# Altium schematic sheet 04_CPU.SchDoc(04_CPU)
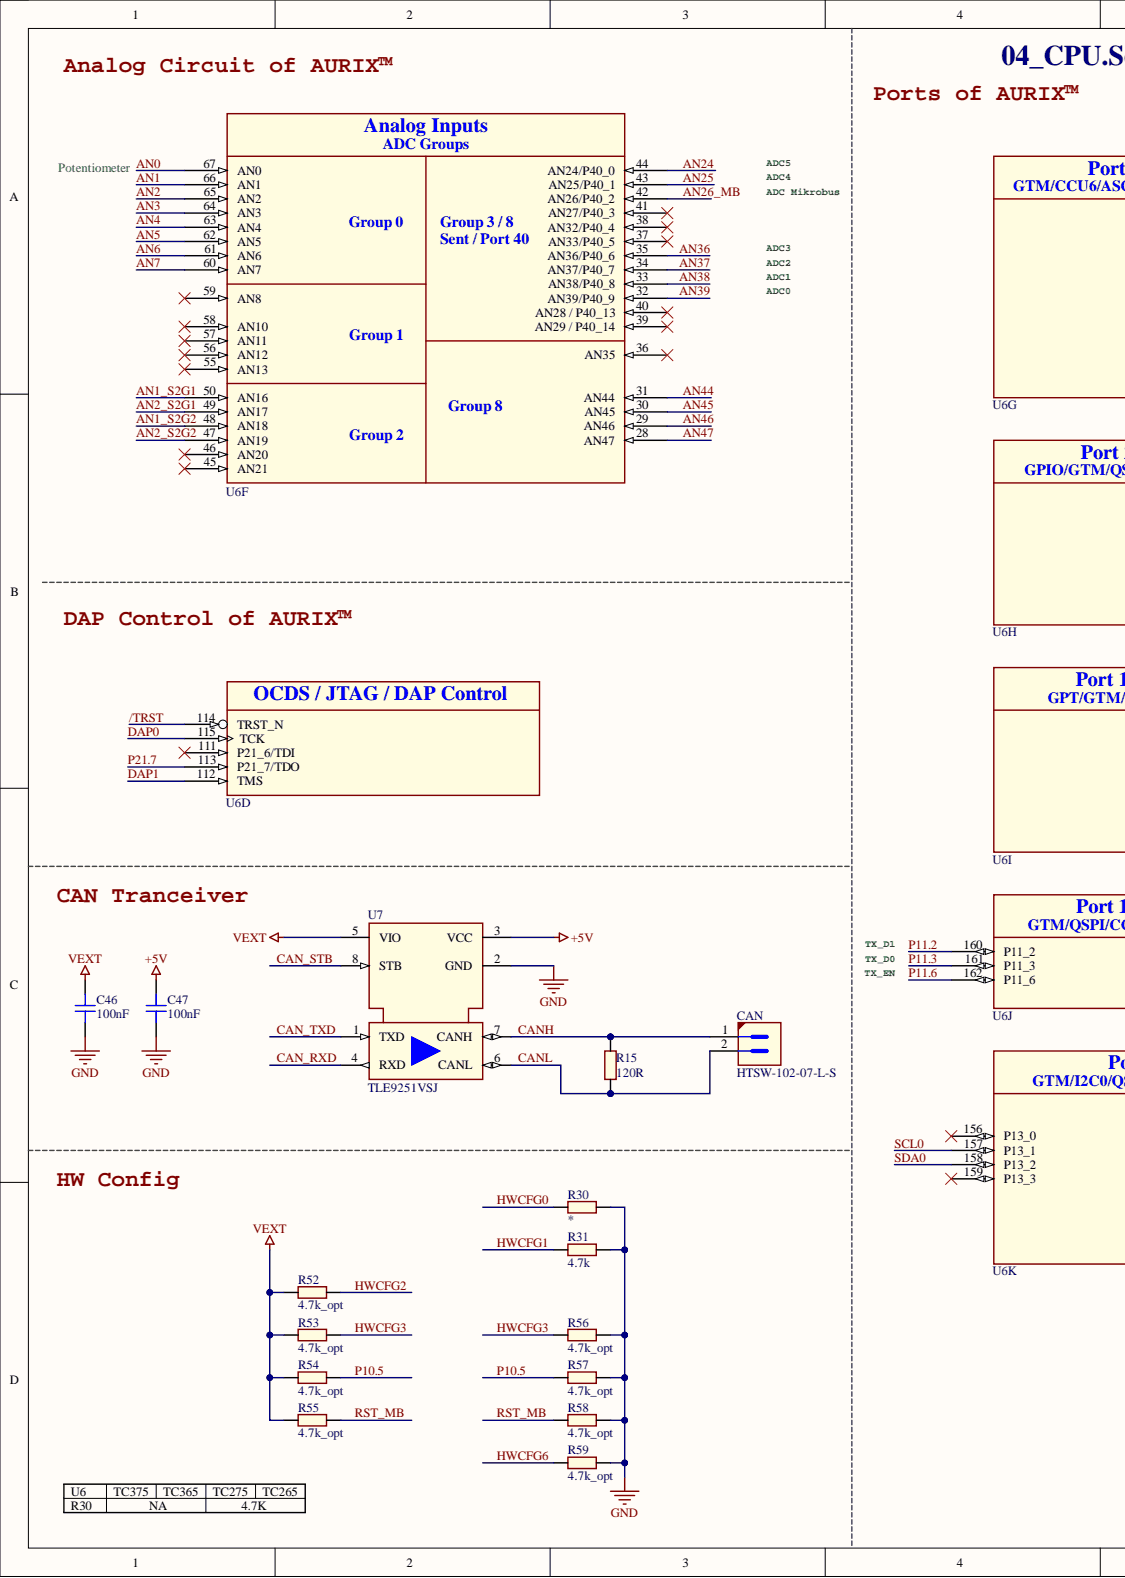
[diagram p0: 04_CPU.SchDoc, left]
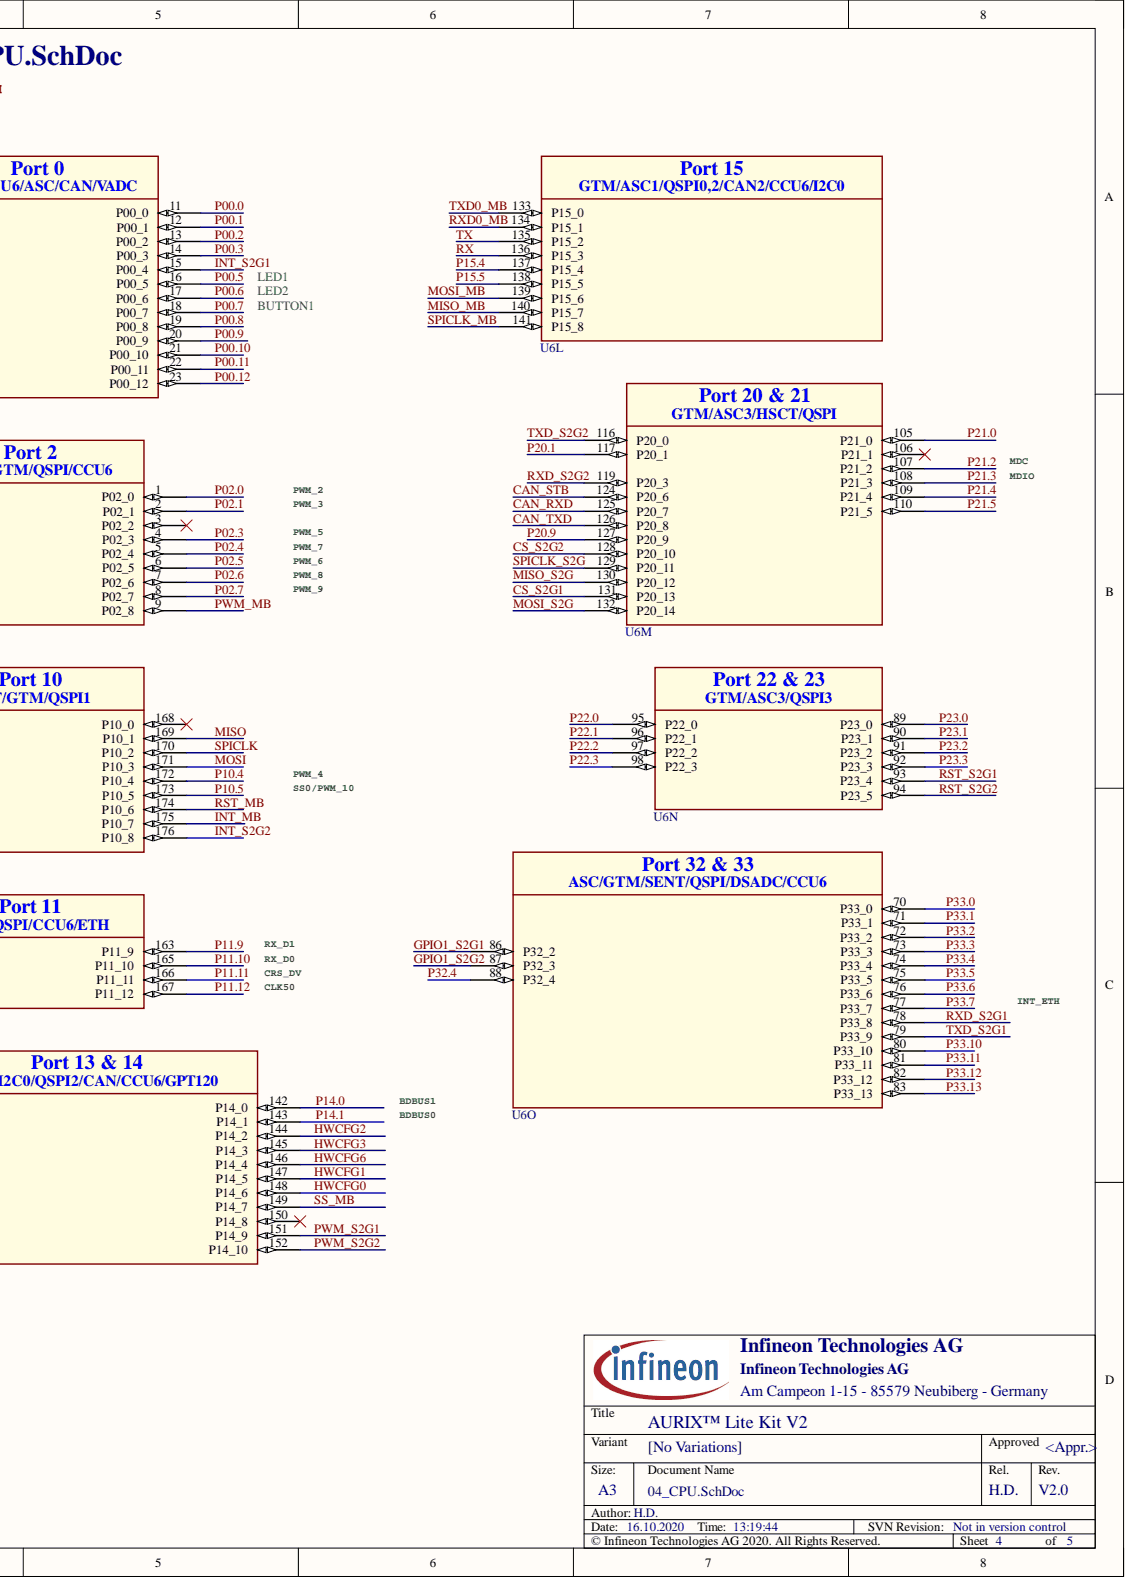
[diagram p1: 04_CPU.SchDoc, right]

## Parts
C46  100nF  [CAPC1005X55N-1]
C47  100nF  [CAPC1005X55N-1]
CAN  HTSW-102-07-L-S  [HDRV2W64P254_1X2_496X248X838B]
R15  120R  [RESC1005X40N]
R30  (no value)  [RESC1609X50N]
R31  4.7k  [RESC1609X50N]
R52  4.7k_opt  [RESC1609X50N]
R53  4.7k_opt  [RESC1609X50N]
R54  4.7k_opt  [RESC1609X50N]
R55  4.7k_opt  [RESC1609X50N]
R56  4.7k_opt  [RESC1609X50N]
R57  4.7k_opt  [RESC1609X50N]
R58  4.7k_opt  [RESC1609X50N]
R59  4.7k_opt  [RESC1609X50N]
U6  TC375  [QFP50P2600X2600X160-177N]  (value not drawn)  (parts D,F,G,H,I,J,K,L,M,N,O)
U7  TLE9251VSJ  [SOIC127P600X175-8N-3]

## Nets
+5V: C47.2 U7.3(VCC)
GND: C46.1 C47.1 R30.2 R31.2 R56.2 R57.2 R58.2 R59.2 U7.2(GND)
VEXT: C46.2 R52.1 R53.1 R54.1 R55.1 U7.5(VIO)
/TRST: U6.114(TRST_N)
AN0: U6.67(AN0)
AN1: U6.66(AN1)
AN1_S2G1: U6.50(AN16)
AN1_S2G2: U6.48(AN18)
AN2: U6.65(AN2)
AN2_S2G1: U6.49(AN17)
AN2_S2G2: U6.47(AN19)
AN3: U6.64(AN3)
AN4: U6.63(AN4)
AN5: U6.62(AN5)
AN6: U6.61(AN6)
AN7: U6.60(AN7)
AN24: U6.44(AN24/P40_0)
AN25: U6.43(AN25/P40_1)
AN26_MB: U6.42(AN26/P40_2)
AN36: U6.35(AN36/P40_6)
AN37: U6.34(AN37/P40_7)
AN38: U6.33(AN38/P40_8)
AN39: U6.32(AN39/P40_9)
AN44: U6.31(AN44)
AN45: U6.30(AN45)
AN46: U6.29(AN46)
AN47: U6.28(AN47)
CAN_RXD: U6.125(P20_7) U7.4(RXD)
CAN_STB: U6.124(P20_6) U7.8(STB)
CAN_TXD: U6.126(P20_8) U7.1(TXD)
CANH: CAN.1 R15.2 U7.7(CANH)
CANL: CAN.2 R15.1 U7.6(CANL)
CS_S2G1: U6.131(P20_13)
CS_S2G2: U6.128(P20_10)
DAP0: U6.115(TCK)
DAP1: U6.112(TMS)
GPIO1_S2G1: U6.86(P32_2)
GPIO1_S2G2: U6.87(P32_3)
HWCFG0: R30.1 U6.148(P14_6)
HWCFG1: R31.1 U6.147(P14_5)
HWCFG2: R52.2 U6.144(P14_2)
HWCFG3: R53.2 R56.1 U6.145(P14_3)
HWCFG6: R59.1 U6.146(P14_4)
INT_MB: U6.175(P10_7)
INT_S2G1: U6.15(P00_4)
INT_S2G2: U6.176(P10_8)
MISO: U6.169(P10_1)
MISO_MB: U6.140(P15_7)
MISO_S2G: U6.130(P20_12)
MOSI: U6.171(P10_3)
MOSI_MB: U6.139(P15_6)
MOSI_S2G: U6.132(P20_14)
P00.0: U6.11(P00_0)
P00.1: U6.12(P00_1) U6.21(P00_10)  [also labelled P00.10]
P00.2: U6.13(P00_2)
P00.3: U6.14(P00_3)
P00.5: U6.16(P00_5)
P00.6: U6.17(P00_6)
P00.7: U6.18(P00_7)
P00.8: U6.19(P00_8)
P00.9: U6.20(P00_9)
P00.11: U6.22(P00_11)
P00.12: U6.23(P00_12)
P02.0: U6.1(P02_0)
P02.1: U6.2(P02_1)
P02.3: U6.4(P02_3)
P02.4: U6.5(P02_4)
P02.5: U6.6(P02_5)
P02.6: U6.7(P02_6)
P02.7: U6.8(P02_7)
P10.4: U6.172(P10_4)
P10.5: R54.2 R57.1 U6.173(P10_5)
P11.2: U6.160(P11_2)
P11.3: U6.161(P11_3)
P11.6: U6.162(P11_6)
P11.9: U6.163(P11_9)
P11.10: U6.165(P11_10)
P11.11: U6.166(P11_11)
P11.12: U6.167(P11_12)
P14.0: U6.142(P14_0)
P14.1: U6.143(P14_1)
P15.4: U6.137(P15_4)
P15.5: U6.138(P15_5)
P20.1: U6.117(P20_1)
P20.9: U6.127(P20_9)
P21.0: U6.105(P21_0)
P21.2: U6.107(P21_2)
P21.3: U6.108(P21_3)
P21.4: U6.109(P21_4)
P21.5: U6.110(P21_5)
P21.7: U6.113(P21_7/TDO)
P22.0: U6.95(P22_0)
P22.1: U6.96(P22_1)
P22.2: U6.97(P22_2)
P22.3: U6.98(P22_3)
P23.0: U6.89(P23_0)
P23.1: U6.90(P23_1)
P23.2: U6.91(P23_2)
P23.3: U6.92(P23_3)
P32.4: U6.88(P32_4)
P33.0: U6.70(P33_0)
P33.1: U6.71(P33_1) U6.80(P33_10)  [also labelled P33.10]
P33.2: U6.72(P33_2)
P33.3: U6.73(P33_3)
P33.4: U6.74(P33_4)
P33.5: U6.75(P33_5)
P33.6: U6.76(P33_6)
P33.7: U6.77(P33_7)
P33.11: U6.81(P33_11)
P33.12: U6.82(P33_12)
P33.13: U6.83(P33_13)
PWM_MB: U6.9(P02_8)
PWM_S2G1: U6.151(P14_9)
PWM_S2G2: U6.152(P14_10)
RST_MB: R55.2 R58.1 U6.174(P10_6)
RST_S2G1: U6.93(P23_4)
RST_S2G2: U6.94(P23_5)
RX: U6.136(P15_3)
RXD0_MB: U6.134(P15_1)
RXD_S2G1: U6.78(P33_8)
RXD_S2G2: U6.119(P20_3)
SCL0: U6.157(P13_1)
SDA0: U6.158(P13_2)
SPICLK: U6.170(P10_2)
SPICLK_MB: U6.141(P15_8)
SPICLK_S2G: U6.129(P20_11)
SS_MB: U6.149(P14_7)
TX: U6.135(P15_2)
TXD0_MB: U6.133(P15_0)
TXD_S2G1: U6.79(P33_9)
TXD_S2G2: U6.116(P20_0)

Not connected: U6 3(P02_2) 36(AN35) 37(AN33/P40_5) 38(AN32/P40_4) 39(AN29_/_P40_14) 40(AN28_/_P40_13) 41(AN27/P40_3) 45(AN21) 46(AN20) 55(AN13) 56(AN12) 57(AN11) 58(AN10) 59(AN8) 106(P21_1) 111(P21_6/TDI) 150(P14_8) 156(P13_0) 159(P13_3) 168(P10_0)

## Library data of the parts
C46 C47 = 308680: Multilayer Ceramic Chip Capacitor; Manufacturer: TDK Corporation; Manufacturer Component Status: Active; Manufacturer Order Number: CGA2B1X7R1C104K050BC; Multiple Parts: No; Alternate View: Yes; Automotive Qualified: AEC Q200; Combined Parameters: TC:X7R (EIA);Tol.:-10%/+10%;Vdc:16V; Legacy DeviceID: CAP01010669; Package Imperial: 0402; Package Metric: 1005; QuickPick: 100nF / 16V / 10% / X7R (EIA) / 0402 (1005); Style: Single; Symbol Type: Capacitor; Single; Standard; 2 Pins; Tolerance Neg: 10%; Type: Standard; Version Number: V01; Voltage DC (max): 16V; Article Number: 308680; Component Kind: Standard; ComponentLink1Description: Vendor WebSite; ComponentLink1URL: http://www.tdk.com; ComponentLink2Description: Datasheet; ComponentLink2URL: http://webnetprod.muc.infineon.com/PCBPortal_Prod/WorkFlows/AltiumComponent/StandardDocumentation?Versionid=308681; Legacy PartNumber: CAP01010669; Package Description: Capacitor,non-polarized,Chip;1.00mm L X 0.50mm W X 0.55mm H; PackageReference: 0402 (1005); Part Type: Capacitor; PLMStatus: Active; ROHS Compliant: Y; Second Source allowed: Yes; Supplier 1: Farnell; Supplier 2: DigiKey; Supplier 3: Mouser; Supplier Part Number 1: 2458205; Supplier Part Number 2: 445-5613-2-ND; Supplier Part Number 3: 810-CGA2B1X7R1C104K; Technology: Ceramic; Temperature max: 125; Temperature min: -55; Temperature Range: Military; Tolerance Pos: 10%; Value: 100nF; Library Name: AURIX_Lite_Kit_V2.0.SCHLIB
CAN = 378188: Through hole .025'' SQ Post Header, 2.54mm pitch, 2 pin, vertical, single row; Legacy PartNumber: CON01500112; Manufacturer: Samtec; Manufacturer Component Status: Active; Alternat View: Yes; Automotive Qualified: No; Combined Parameters: Board-to-Board Header (male);2x1;Mounting:THT;Orient:0Â° (vertical);Shielded:No; Legacy DeviceID: SAM_HTSW-102-07-L-S; QuickPick: 0Â° (vertical) / Board-to-Board; Style: Strip; Version Number: V01; Voltage DC (max): na; Article Number: 378188; Component Kind: Standard; ComponentLink1Description: Vendor WebSite; ComponentLink1URL: http://www.samtec.com/; ComponentLink2Description: Datasheet; ComponentLink2URL: http://webnetprod.muc.infineon.com/PCBPortal_Prod/WorkFlows/AltiumComponent/StandardDocumentation?Versionid=378189; Manufacturer Order Number: HTSW-102-07-L-S; Package Description: Header,vertical,2.54mm pitch;0.64mm lead width,2 pins,1 row,2 pins per row,4.955mm L X 2.48mm W X 8.38mm H body; Part Type: Connector; PLMStatus: Active; ROHS Compliant: Y; Second Source allowed: Yes; Supplier 1: Farnell; Supplier 2: DigiKey; Supplier 3: Mouser; Supplier Part Number 1: 1925450; Supplier Part Number 2: HTSW-102-07-L-S-ND; Temperature max: 125; Temperature min: -55; Temperature Range: Military; Type: Board-to-Board; Value: HTSW-102-07-L-S; Library Name: AURIX_Lite_Kit_V2.0.SCHLIB
R15 = 341067: Standard Thick Film Chip Resistor; Manufacturer: Vishay; Manufacturer Component Status: Active; Manufacturer Order Number: CRCW0402120RFK; Multiple Parts: No; Alternate View: Yes; Automotive Qualified: AEC Q200; Combined Parameters: TCR:100ppm/K;Tol.:-1%/+1%;Vdc:50V;Idc:-;P:63mW; Legacy DeviceID: RES01800237; QuickPick: 120R / 1% / 0.0630 / 0402; Style: Single; Symbol Type: Resistor; Single; Standard; 2 Pins; Tolerance Neg: 1%; Version Number: V01; Voltage DC (max): 50V; Article Number: 341067; Component Kind: Standard; ComponentLink1Description: Vendor WebSite; ComponentLink1URL: http://www.vishay.com; ComponentLink2Description: Datasheet; ComponentLink2URL: http://webnetprod.muc.infineon.com/PCBPortal_Prod/WorkFlows/AltiumComponent/StandardDocumentation?Versionid=341068; Legacy PartNumber: RES01800237; PackageReference: 0402; Part Type: Resistor; PLMStatus: Active; ROHS Compliant: Y; Second Source allowed: Yes; Supplier 1: Farnell; Supplier 2: DigiKey; Supplier 3: Mouser; Technology: Thick Film; Temperature max: 155; Temperature min: -55; Temperature Range: Military; Tolerance Pos: 1%; Type: Standard; Value: 120R; Library Name: AURIX_Lite_Kit_V2.0.SCHLIB
R30 R52-R59 = 345982: Standard Thick Film Chip Resistor; Manufacturer: Vishay; Manufacturer Order Number: CRCW06034K70FK; Multiple Parts: No; Alternate View: Yes; Automotive Qualified: AEC Q200; Combined Parameters: TCR:100ppm/K;Tol.:-1%/+1%;Vdc:75V;Idc:-;P:100mW; Legacy DeviceID: RES01801219; PLMStatus: Active; QuickPick: 4.7k / 1% / 0.10 / 0603; Style: Single; Symbol Type: Resistor; Single; Standard; 2 Pins; Tolerance Neg: 1%; Value: 4.7k; Version Number: V01; Voltage DC (max): 75V; Article Number: 345982; Component Kind: Standard; ComponentLink1Description: Vendor WebSite; ComponentLink1URL: http://www.vishay.com; ComponentLink2Description: Datasheet; ComponentLink2URL: http://webnetprod.muc.infineon.com/PCBPortal_Prod/WorkFlows/AltiumComponent/StandardDocumentation?Versionid=345983; Legacy PartNumber: RES01801219; PackageReference: 0603; Part Type: Resistor; ROHS Compliant: Y; Second Source allowed: Yes; Supplier 1: Farnell; Supplier 2: DigiKey; Supplier 3: Mouser; Technology: Thick Film; Temperature max: 155; Temperature min: -55; Temperature Range: Military; Tolerance Pos: 1%; Type: Standard; Library Name: AURIX_Lite_Kit_V2.0.SCHLIB
R31 = 345982: Standard Thick Film Chip Resistor; Manufacturer: Vishay; Manufacturer Order Number: CRCW06034K70FK; Multiple Parts: No; Alternate View: Yes; Automotive Qualified: AEC Q200; Combined Parameters: TCR:100ppm/K;Tol.:-1%/+1%;Vdc:75V;Idc:-;P:100mW; Legacy DeviceID: RES01801219; PLMStatus: Active; QuickPick: 4.7k / 1% / 0.10 / 0603; Style: Single; Symbol Type: Resistor; Single; Standard; 2 Pins; Tolerance Neg: 1%; Version Number: V01; Voltage DC (max): 75V; Article Number: 345982; Component Kind: Standard; ComponentLink1Description: Vendor WebSite; ComponentLink1URL: http://www.vishay.com; ComponentLink2Description: Datasheet; ComponentLink2URL: http://webnetprod.muc.infineon.com/PCBPortal_Prod/WorkFlows/AltiumComponent/StandardDocumentation?Versionid=345983; Legacy PartNumber: RES01801219; PackageReference: 0603; Part Type: Resistor; ROHS Compliant: Y; Second Source allowed: Yes; Supplier 1: Farnell; Supplier 2: DigiKey; Supplier 3: Mouser; Technology: Thick Film; Temperature max: 155; Temperature min: -55; Temperature Range: Military; Tolerance Pos: 1%; Type: Standard; Value: 4.7k; Library Name: AURIX_Lite_Kit_V2.0.SCHLIB
U6 = 420527_NEW: 32-Bit Single-Chip Microcontroller with Hardware Security Mode; Legacy PartNumber: IFX01400135_NEW; Manufacturer: Infineon Technologies; Manufacturer Component Status: Active; Manufacturer Order Number: SAK-TC375TP-96F300W; Automotive Qualified: No; Combined Parameters: App.:-;-;Vdc min/max:-;Fmax:-;RAM:-;ROM:-;Flash:-; Legacy DeviceID: IFX_SAK-TC375TP-96F300W; Second Source allowed: Yes; Symbol type: TC375 32-Bit Single-Chip Microcontroller; Value: IFX_SAK-TC375TP-96F300W; Version Number: V01; Voltage DC (max): na; Article Number: 420527_NEW; Component Kind: Standard; ComponentLink1Description: Vendor WebSite; ComponentLink1URL: https://www.infineon.com/; ComponentLink2Description: Datasheet; ComponentLink2URL: http://webnetprod.muc.infineon.com/pcbportal_prod/WorkFlows/AltiumComponent/StandardDocumentation?Versionid=420528; Package Description: QFP,0.50mm pitch,square;176 pin,24.00mm L X 24.00mm W X 1.60mm H body (w/thermal tab); PackageReference: PG-LQFP-176; Part Type: Microcontroller; PLMStatus: Active; RoHS Compliant: Y; Supplier 1: Farnell; Supplier 2: DigiKey; Supplier 3: Mouser; Temperature Range: Automotive; Library Name: AURIX_Lite_Kit_V2.0.SCHLIB
U7 = 426006: High Speed CAN Transceiver; Legacy PartNumber: IFX01400833; Manufacturer: Infineon Technologies; Automotive Qualified: Yes; Combined Parameters: - -;Vdc min/max:-;Iout:-;Fmax:-; Legacy DeviceID: IFX_TLE9251VSJ; PLMStatus: Active; QuickPick: PG-DSO-8-44; Version Number: V01; Voltage DC (max): na; Article Number: 426006; Component Kind: Standard; ComponentLink1Description: Vendor WebSite; ComponentLink1URL: https://www.infineon.com/; ComponentLink2Description: Datasheet; ComponentLink2URL: http://webnetprod.muc.infineon.com/PCBPortal_Prod/WorkFlows/AltiumComponent/StandardDocumentation?Versionid=426007; Manufacturer Order Number: TLE9251VSJ; Package Description: SOIC,1.27mm pitch;8 pin,3.90mm W X 4.90mm L X 1.75mm H body; PackageReference: PG-DSO-8-44; Part Type: Integrated Circuit; Second Source allowed: Yes; Supplier 1: Farnell; Supplier 2: DigiKey; Supplier 3: Mouser; Symbol Type: High Speed CAN Transceiver; Temperature Range: Military; Value: TLE9251VSJ; Library Name: AURIX_Lite_Kit_V2.0.SCHLIB
